# Altium schematic sheet DMP_SEM_SDCARD.SchDoc(DMP_SEM_SDCARD)
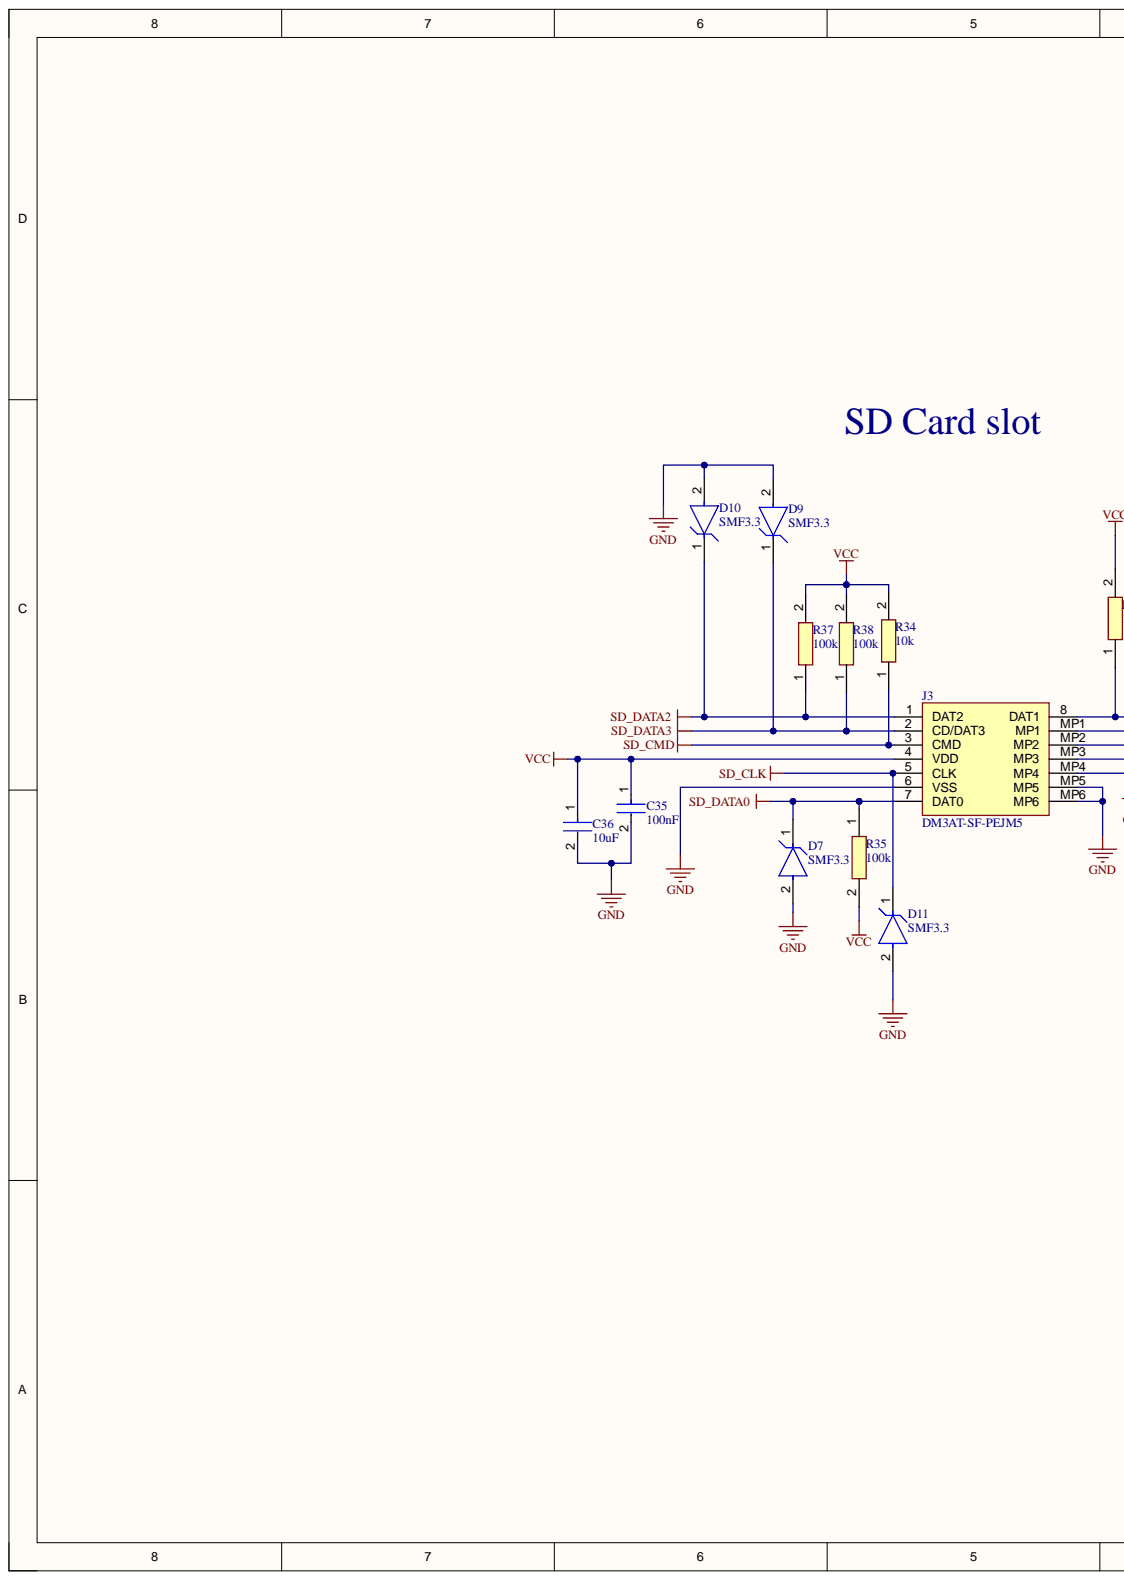
[diagram p0: DMP_SEM_SDCARD.SchDoc, left]
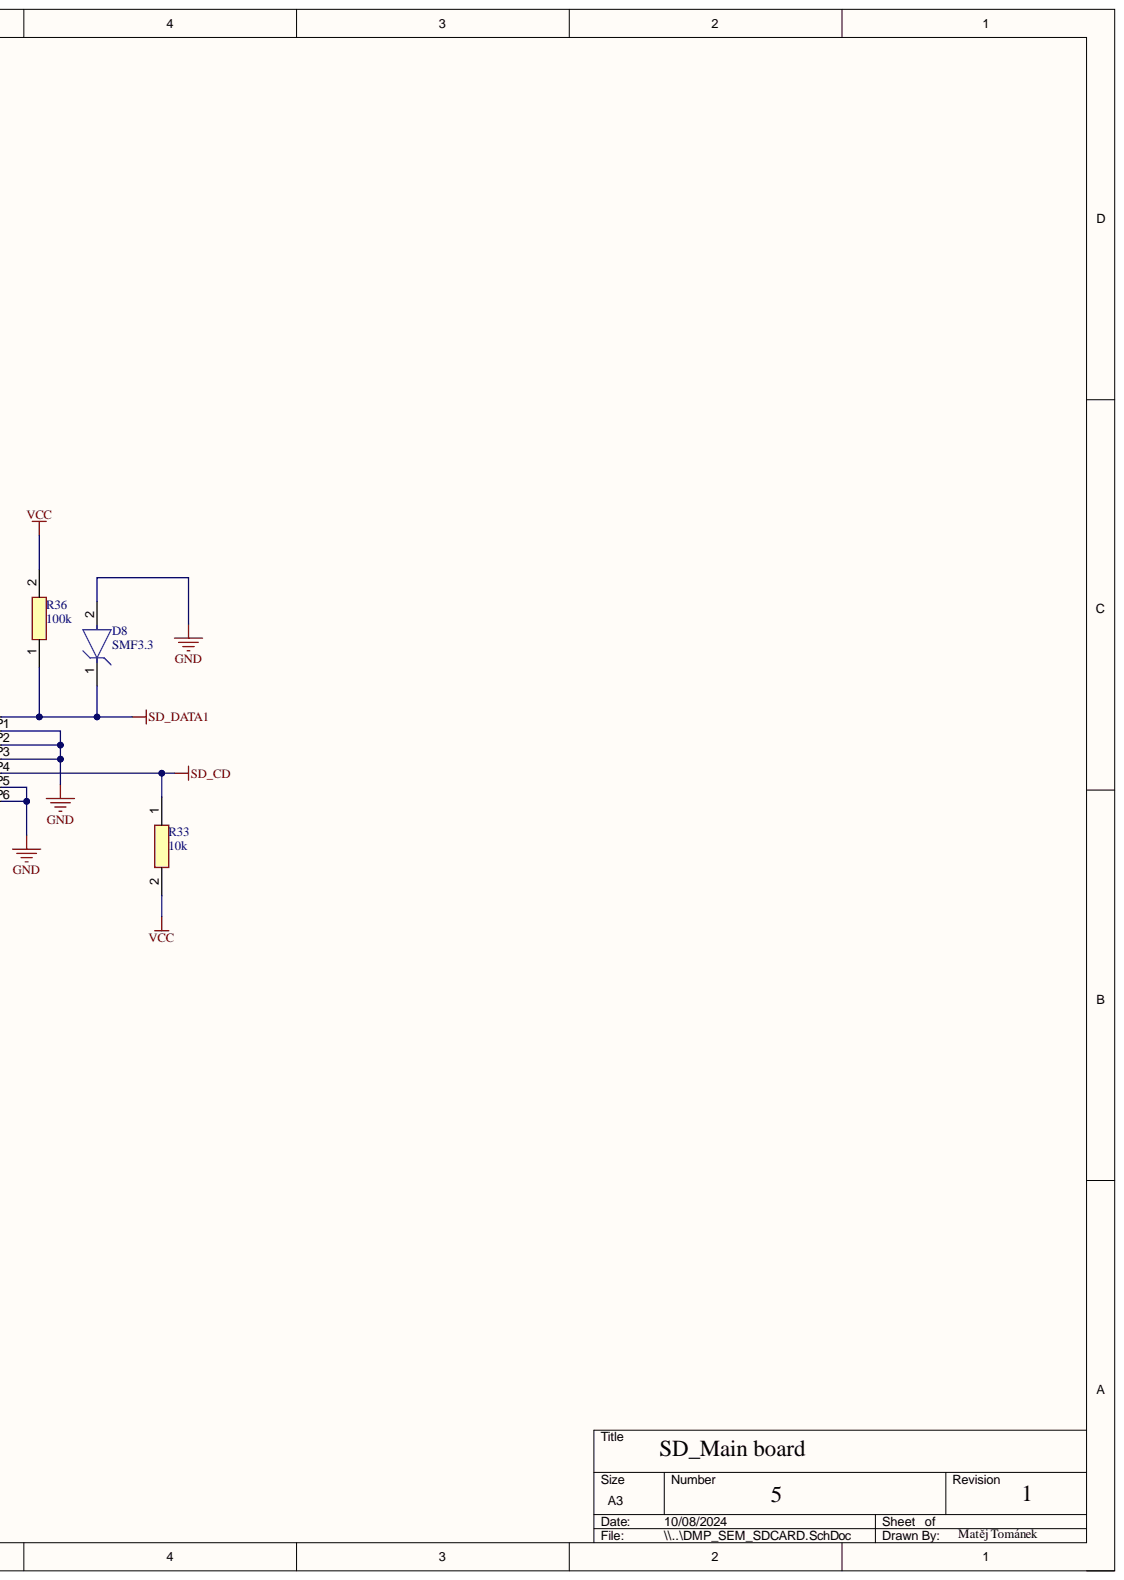
[diagram p1: DMP_SEM_SDCARD.SchDoc, right]

## Parts
C35  100nF  [CL05B104KO5NNNC]
C36  10uF  [CL10A106KO8NQNC]
D7  SMF3.3  [SMF3.3]
D8  SMF3.3  [SMF3.3]
D9  SMF3.3  [SMF3.3]
D10  SMF3.3  [SMF3.3]
D11  SMF3.3  [SMF3.3]
J3  DM3AT-SF-PEJM5  [DM3ATSFPEJM5]
R33  10k  [CRCW060310K0FKEA]
R34  10k  [CRCW060310K0FKEA]
R35  100k  [ERJ-2RKF1003X]
R36  100k  [ERJ-2RKF1003X]
R37  100k  [ERJ-2RKF1003X]
R38  100k  [ERJ-2RKF1003X]

## Nets
GND: C35.2 C36.2 D7.2 D8.2 D9.2 D10.2 D11.2 J3.6(VSS) J3.MP1 J3.MP2 J3.MP3 J3.MP5 J3.MP6
SD_CD: J3.MP4 R33.1
SD_CLK: D11.1 J3.5(CLK)
SD_CMD: J3.3(CMD) R34.1
SD_DATA0: D7.1 J3.7(DAT0) R35.1
SD_DATA1: D8.1 J3.8(DAT1) R36.1
SD_DATA2: D10.1 J3.1(DAT2) R37.1
SD_DATA3: D9.1 J3.2(CD/DAT3) R38.1
VCC: C35.1 C36.1 J3.4(VDD) R33.2 R34.2 R35.2 R36.2 R37.2 R38.2

## Library data of the parts
C35 = CL05B104KO5NNNC: Capacitor CL05B104KO5NNNC; Component Kind: Standard; Library Name: DMP_Schlib_SEM_MAIN_BOARD.SchLib
C36 = CL10A106KO8NQNC: Capacitor CL10A106KO8NQNC; Component Kind: Standard; Library Name: DMP_Schlib_SEM_MAIN_BOARD.SchLib
D7-D11 = SMF3.3: TVS diode SMF3.3; Component Kind: Standard; Library Name: DMP_Schlib_SEM_MAIN_BOARD.SchLib
J3 = DM3AT-SF-PEJM5: Connector; Manufacturer_Name: Hirose; Component Kind: Standard; Datasheet Link: https://datasheet.lcsc.com/szlcsc/Hirose-HRS-DM3AT-SF-PEJM5_C114218.pdf; Height: 1.83mm; Manufacturer_Part_Number: DM3AT-SF-PEJM5; Mouser Part Number: 798-DM3AT-SF-PEJM5; Mouser Price/Stock: https://www.mouser.co.uk/ProductDetail/Hirose-Connector/DM3AT-SF-PEJM5?qs=LZSZKJVF%252B2WTDKp%252BR7IYAQ%3D%3D
R33 R34 = CRCW060310K0FKEA: Resistor CRCW060310K0FKEA; Component Kind: Standard; Library Name: DMP_Schlib_SEM_MAIN_BOARD.SchLib
R35-R38 = ERJ-2RKF1003X: Resistor; Component Kind: Standard; Library Name: DMP_Schlib_SEM_MAIN_BOARD.SchLib
